# Altium schematic sheet CAN.SchDoc(CAN)
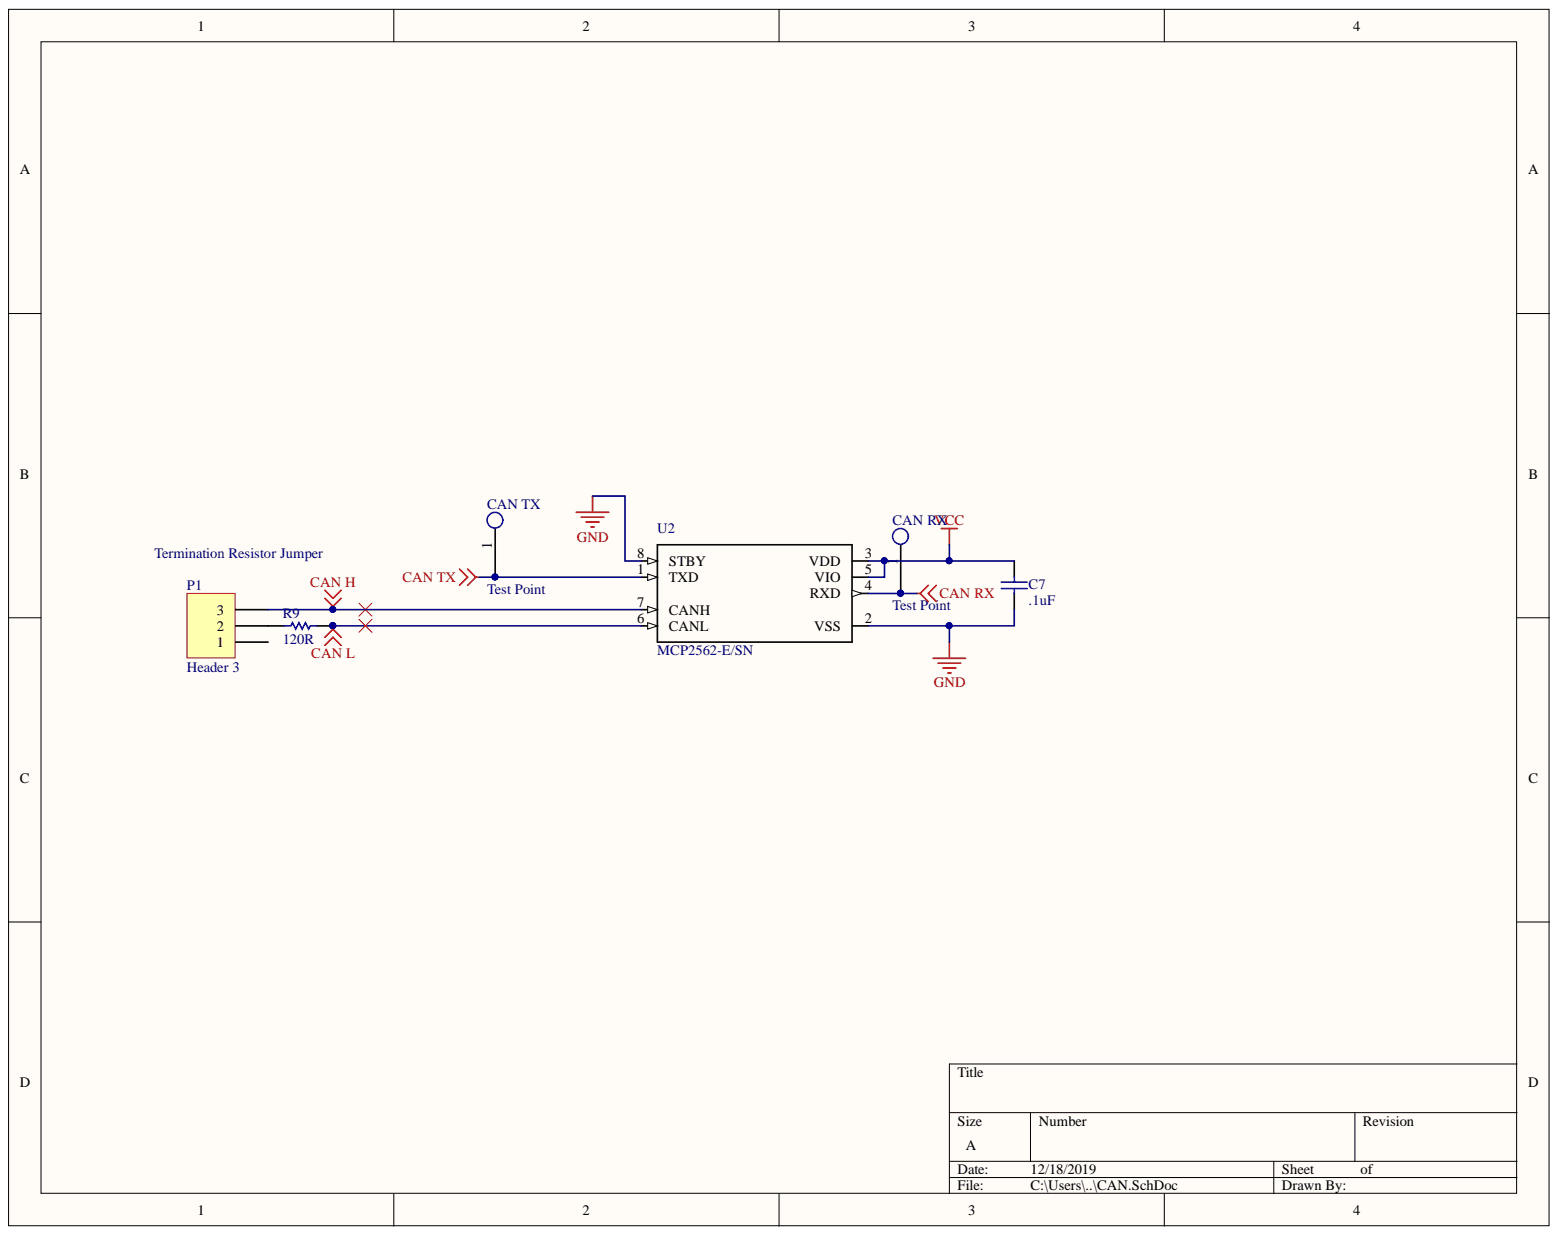
[diagram p0: CAN.SchDoc]

## Parts
C7  .1uF  [CAPC0805(2012)130_N]
CAN_RX  Test Point  [Test Point Through-hole]
CAN_TX  Test Point  [Test Point Through-hole]
P1  Header 3  [HDR1X3]
R9  120R  [RESC0805(2012)_N]
U2  MCP2562-E/SN  [SOIC127P600X175-8N]

## Nets
CAN H: P1.3 U2.7(CANH)
CAN L: R9.2 U2.6(CANL)
CAN RX: CAN_RX.1 U2.4(RXD)
CAN TX: CAN_TX.1 U2.1(TXD)
GND: C7.1 U2.2(VSS) U2.8(STBY)
VCC: C7.2 U2.3(VDD) U2.5(VIO)
NetP1_2: P1.2 R9.1

Not connected: P1 1

## Library data of the parts
C7 = CMP-1036-02603-1: CAP 390pF 200V ±5% 0805 (2012 Metric) Thickness 1.3mm SMD; Tolerance: Â±5%; Case-Metric: 2012; Case-EIA: 0805; Value: 390pF; Technology: SMT; Rated Voltage: 200 V; Max Thickness: 1.3 mm; Library Name: Altium Content Vault; Component Kind: Standard
P1 = Header 3: Header, 3-Pin; Signal Integrity: Connector; Library Name: Miscellaneous Connectors.IntLib; LatestRevisionNote: Re-released for DXP Platform.; LatestRevisionDate: 17-Jul-2002; Component Kind: Standard; Publisher: Altium Limited
R9 = 120R 5% 0805: 120R 0.125W 5% 0805 (2012 Metric)  SMD; Value: 120.00 Ohm; Case-Metric: 2012; Case-EIA: 0805; Tolerance: 5 %; Technology: SMT; Power: 0.125 W; Component Kind: Standard; Library Name: Husky Robotics Standard Library 2019-2020.IntLib
U2 = MCP2562-E/SN: Microchip MCP2562-E/SN, CAN Transceiver 1MBps 1-channel IEC 61000-4-2, 8-Pin SOIC; SnapEDA_Link: https://www.snapeda.com/parts/MCP2562-E/SN/Microchip/view-part/?ref=snap; Price: None; Package: SO-8 Microchip; MP: MCP2562-E/SN; MF: Microchip; Component Kind: Standard; Availability: Unavailable; Library Name: Husky Robotics Standard Library 2019-2020.IntLib
CAN_RX CAN_TX = Test Point: Component Kind: Standard; Library Name: Husky Robotics Standard Library 2019-2020.IntLib
